# Altium schematic sheet medibox.SchDoc
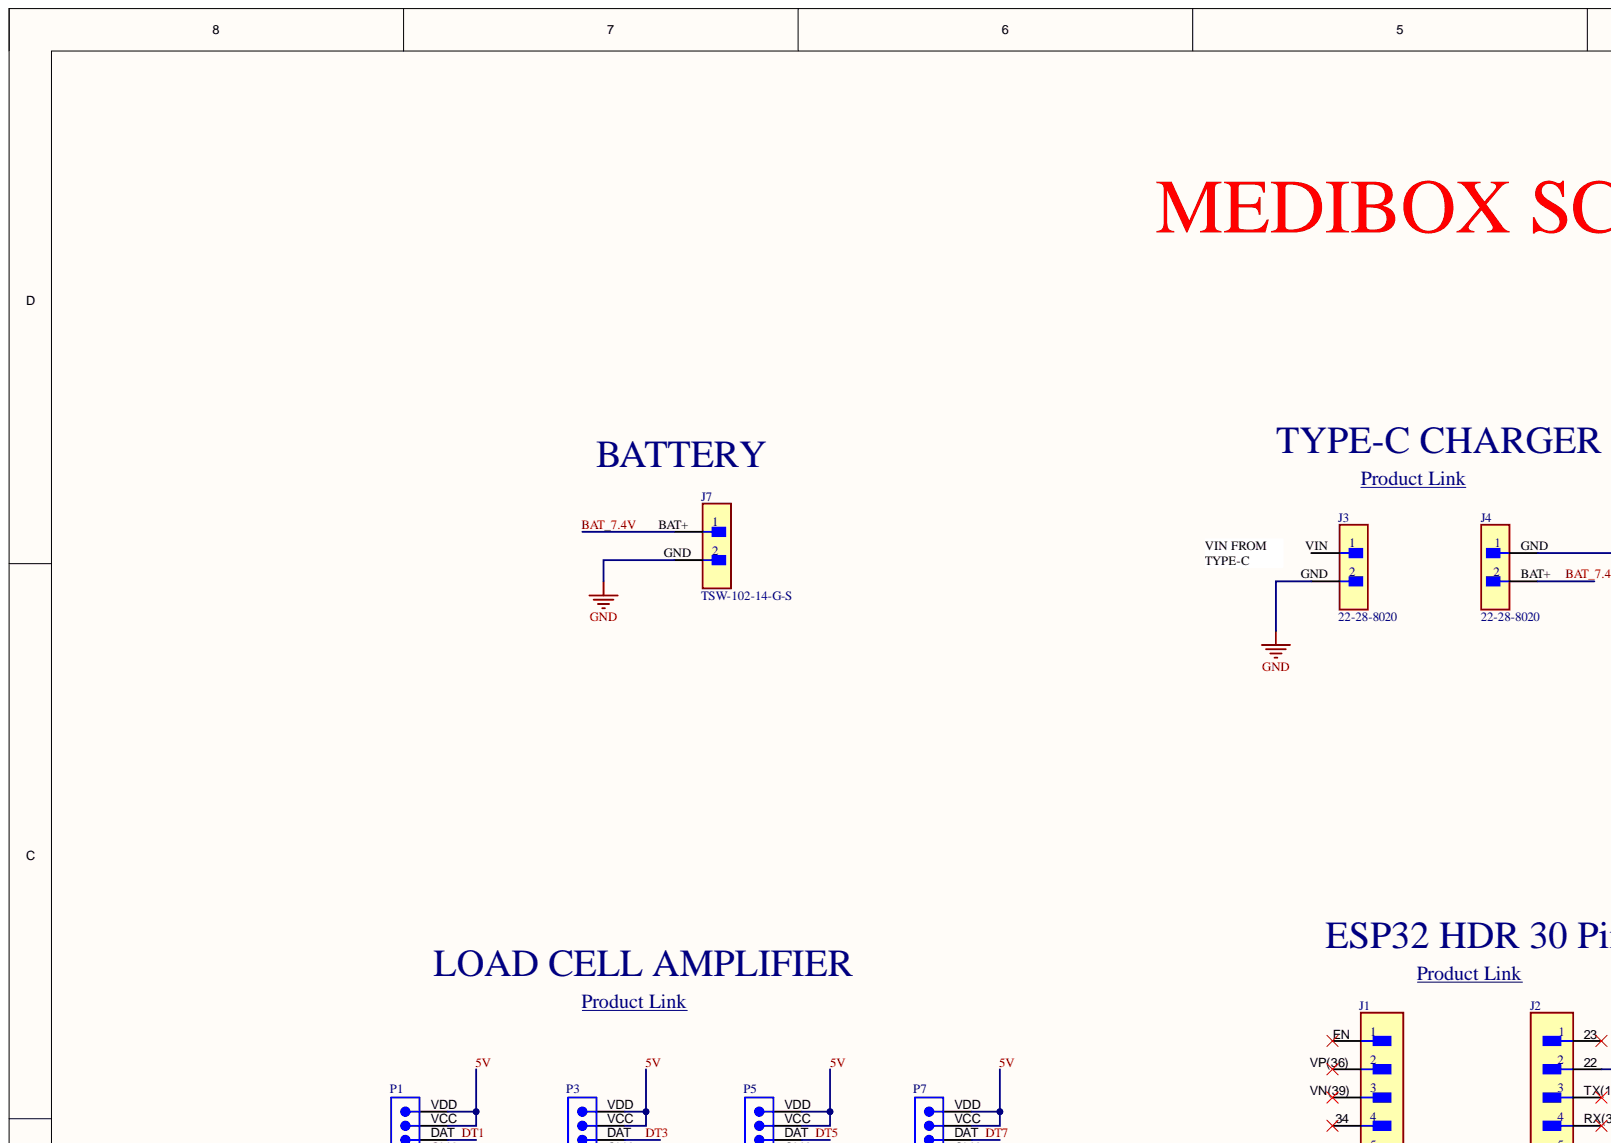
[diagram p0: medibox.SchDoc, top left]
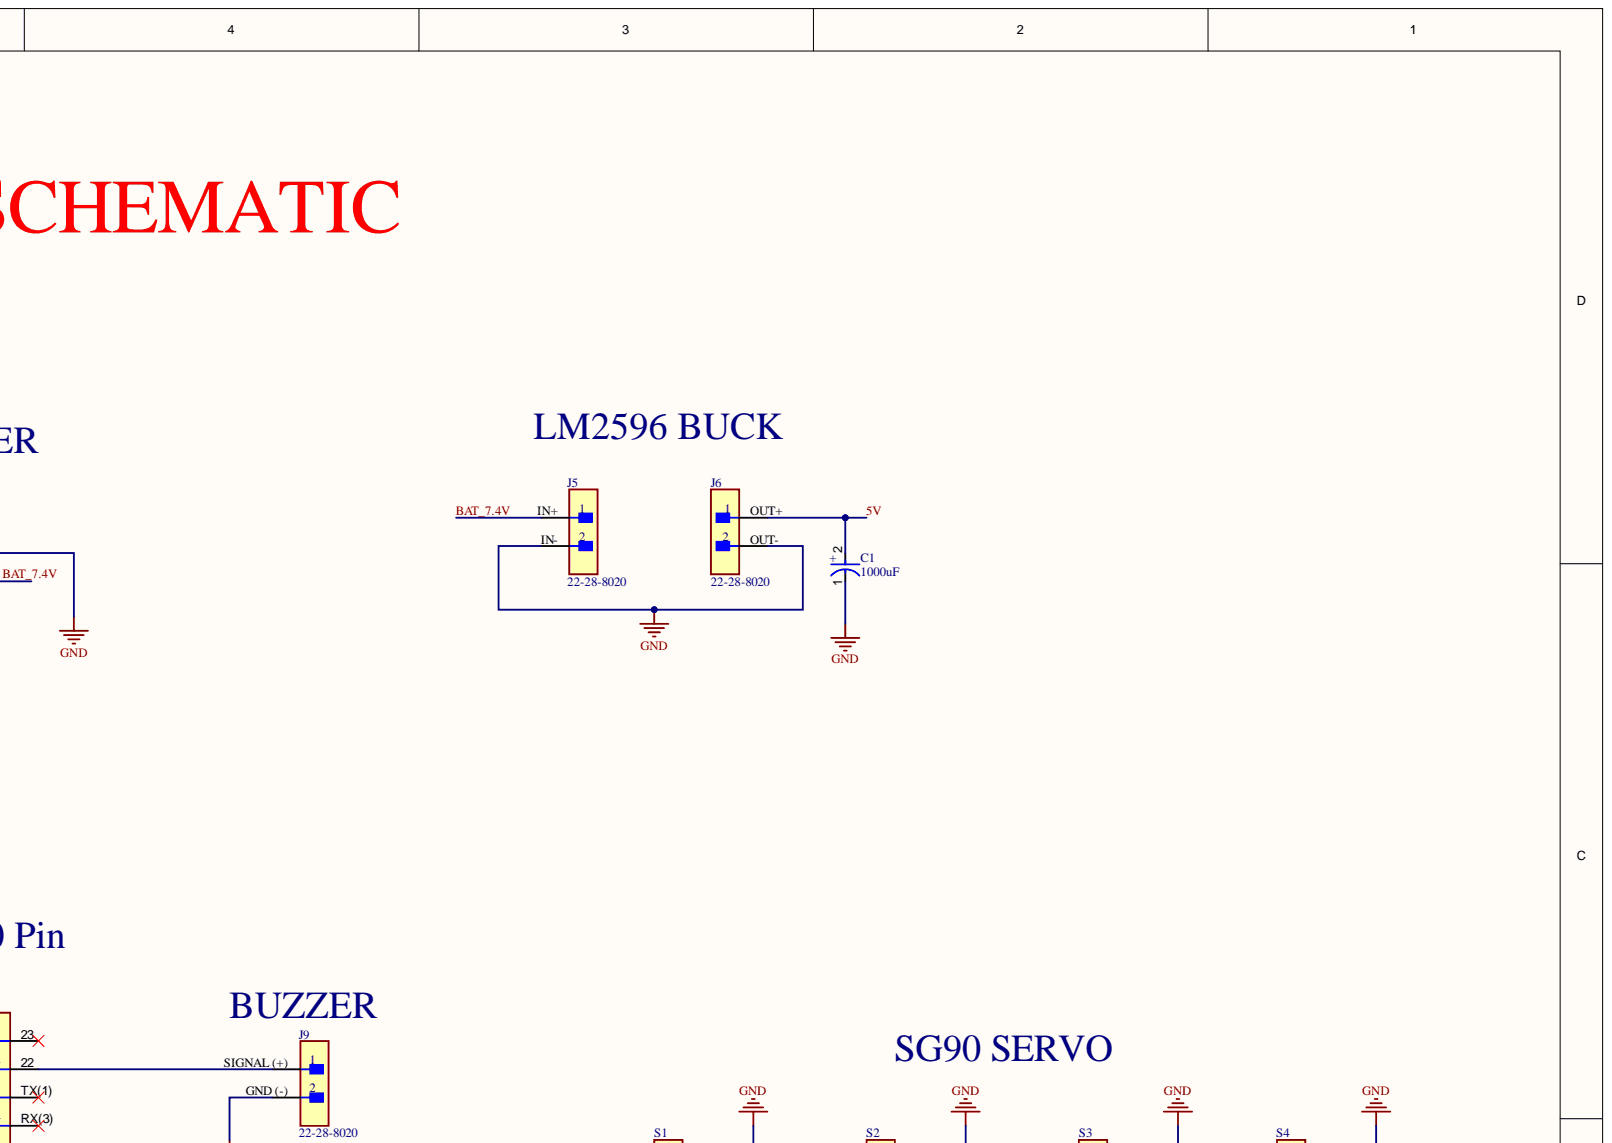
[diagram p1: medibox.SchDoc, top right]
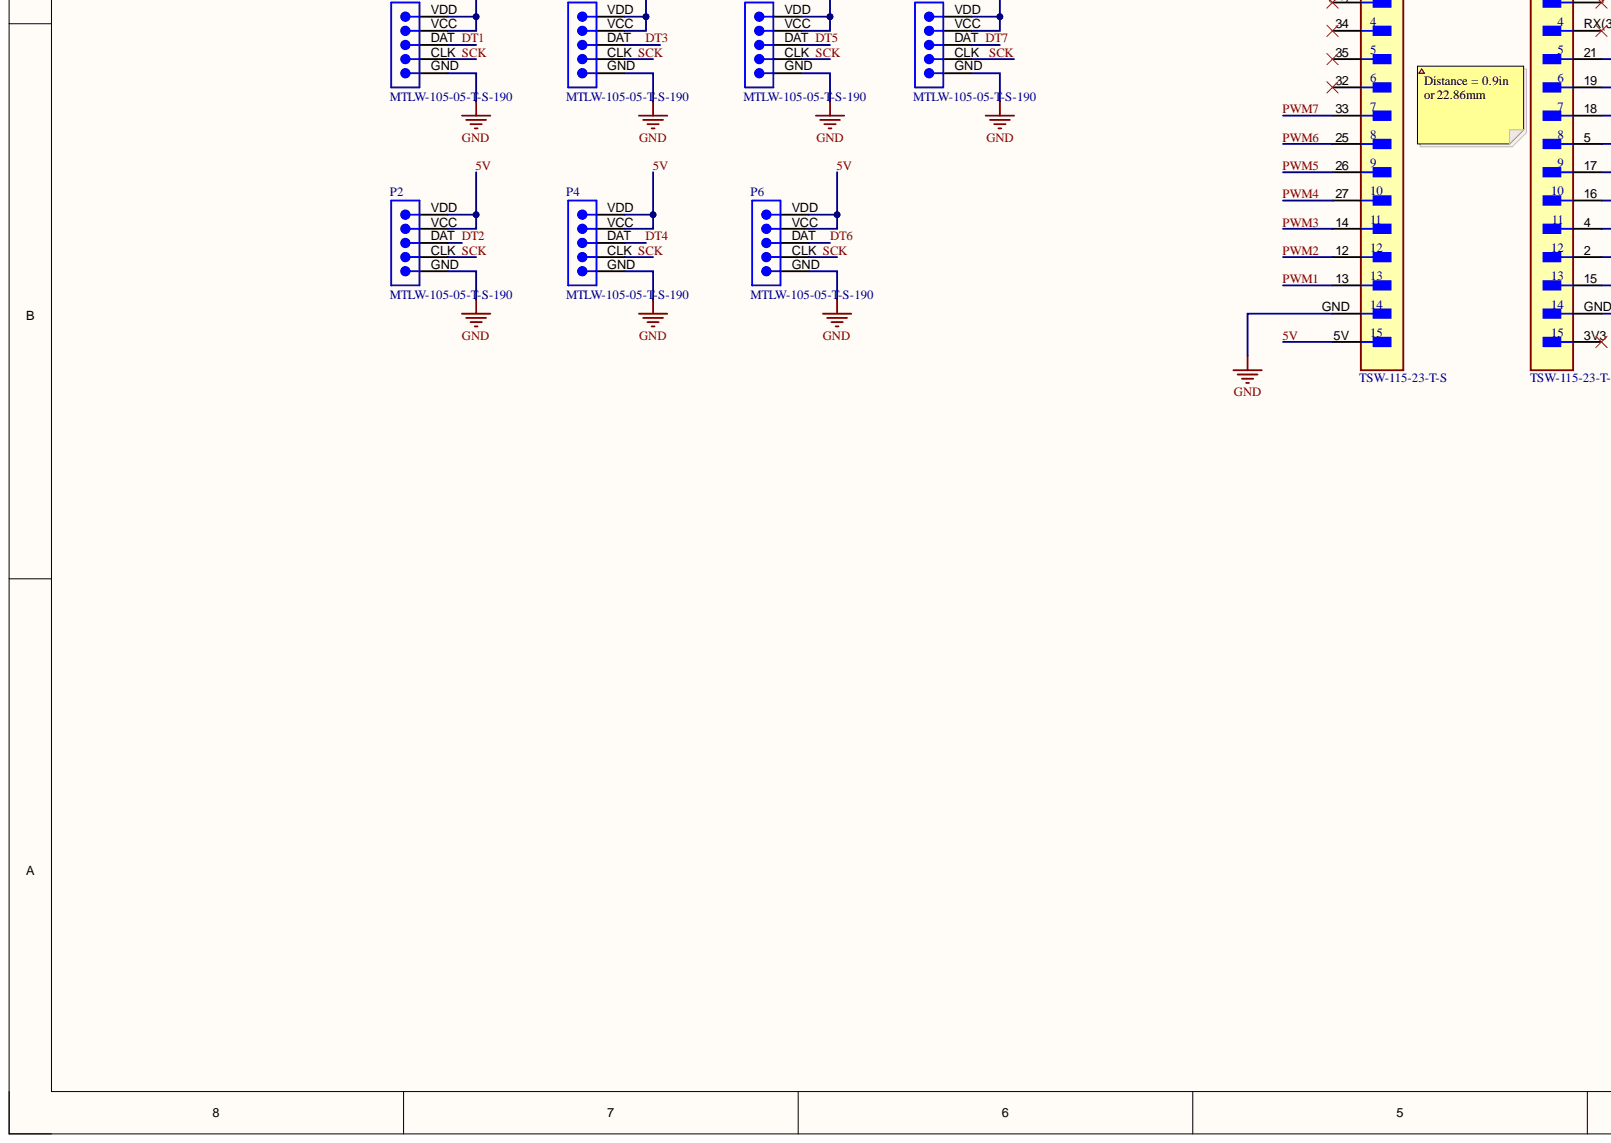
[diagram p2: medibox.SchDoc, bottom left]
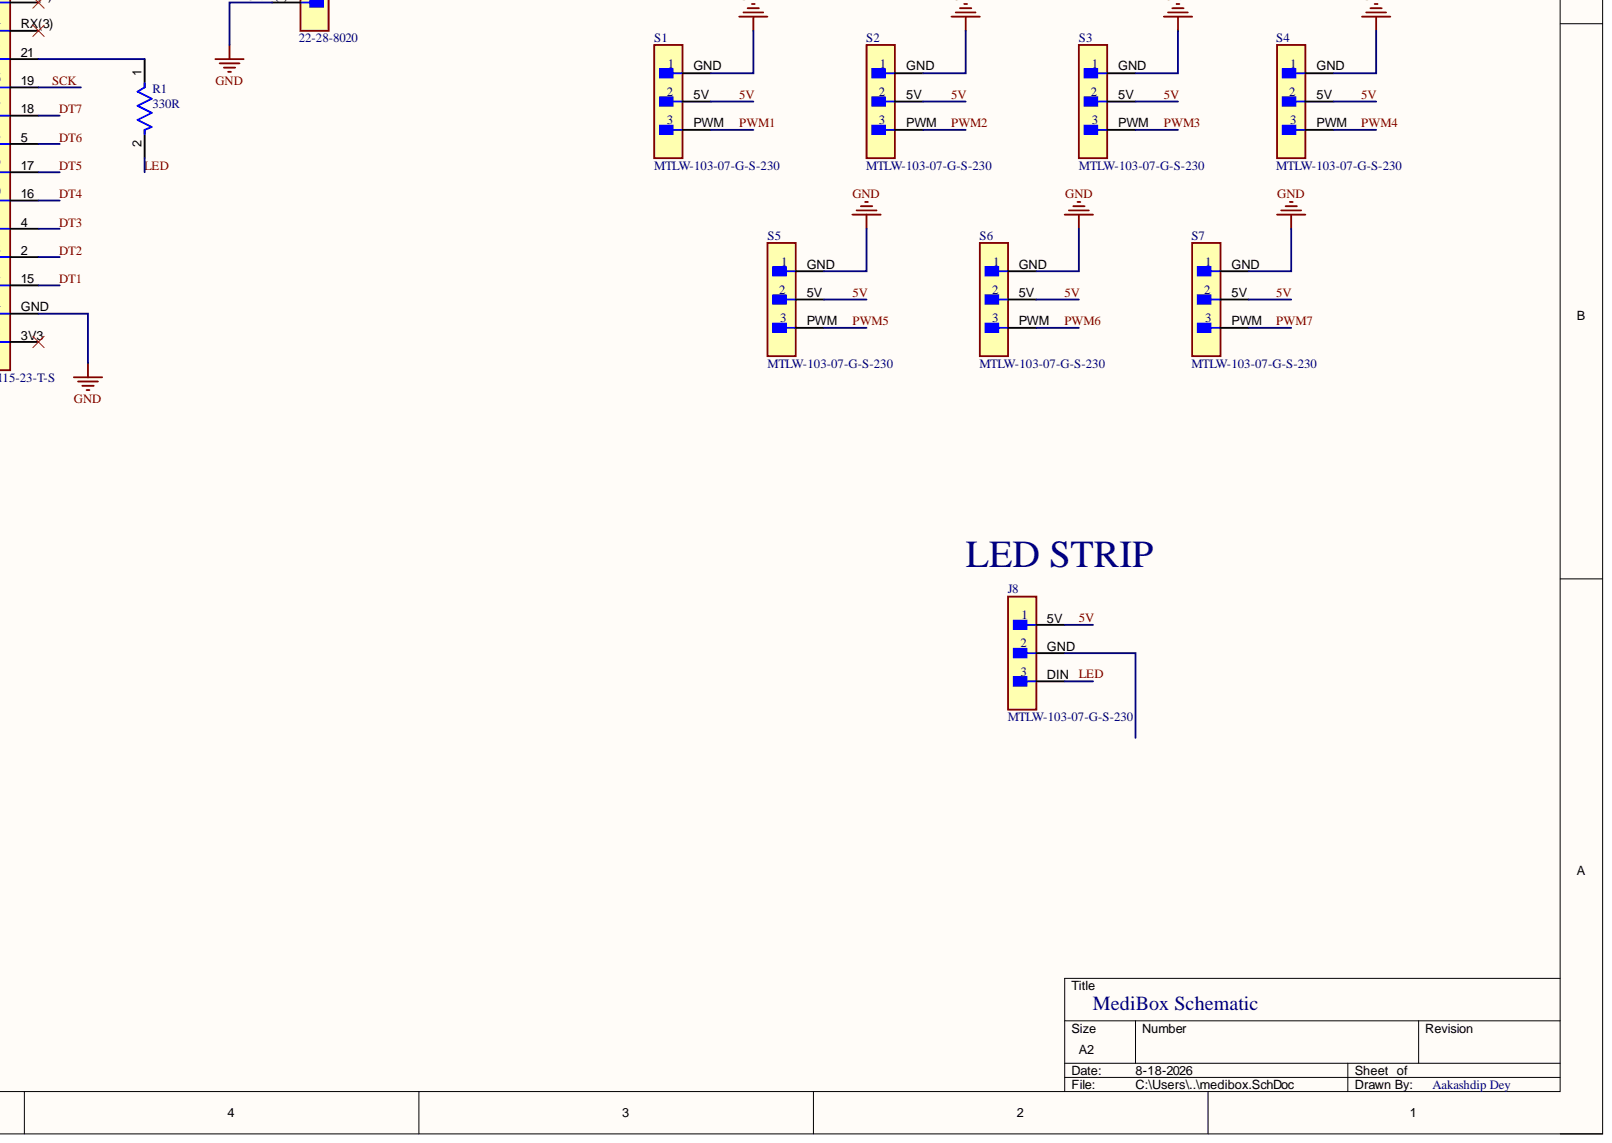
[diagram p3: medibox.SchDoc, bottom right]

## Parts
C1  1000uF  [FP-RAD-TH-D_10_0_5-L_25_2-MFG]
J1  TSW-115-23-T-S  [FP-TSW-115-23-T-S-MFG]
J2  TSW-115-23-T-S  [FP-TSW-115-23-T-S-MFG]
J3  22-28-8020  [FP-22-28-8020-MFG]
J4  22-28-8020  [FP-22-28-8020-MFG]
J5  22-28-8020  [FP-22-28-8020-MFG]
J6  22-28-8020  [FP-22-28-8020-MFG]
J7  TSW-102-14-G-S  [FP-TSW-102-14-G-S-MFG]
J8  MTLW-103-07-G-S-230  [FP-MTLW-103-07-G-S-230-MFG]
J9  22-28-8020  [FP-22-28-8020-MFG]
P1  MTLW-105-05-T-S-190  [SMTC-MTLW-105-05-X-S-190]
P2  MTLW-105-05-T-S-190  [SMTC-MTLW-105-05-X-S-190]
P3  MTLW-105-05-T-S-190  [SMTC-MTLW-105-05-X-S-190]
P4  MTLW-105-05-T-S-190  [SMTC-MTLW-105-05-X-S-190]
P5  MTLW-105-05-T-S-190  [SMTC-MTLW-105-05-X-S-190]
P6  MTLW-105-05-T-S-190  [SMTC-MTLW-105-05-X-S-190]
P7  MTLW-105-05-T-S-190  [SMTC-MTLW-105-05-X-S-190]
R1  330R  [FP-RMCF0805-IPC_C]
S1  MTLW-103-07-G-S-230  [FP-MTLW-103-07-G-S-230-MFG]
S2  MTLW-103-07-G-S-230  [FP-MTLW-103-07-G-S-230-MFG]
S3  MTLW-103-07-G-S-230  [FP-MTLW-103-07-G-S-230-MFG]
S4  MTLW-103-07-G-S-230  [FP-MTLW-103-07-G-S-230-MFG]
S5  MTLW-103-07-G-S-230  [FP-MTLW-103-07-G-S-230-MFG]
S6  MTLW-103-07-G-S-230  [FP-MTLW-103-07-G-S-230-MFG]
S7  MTLW-103-07-G-S-230  [FP-MTLW-103-07-G-S-230-MFG]

## Nets
GND: C1.1 J1.GND J2.GND J3.GND J4.GND J5.IN- J6.OUT- J7.GND J9.GND (-) P1.GND P2.GND P3.GND P4.GND P5.GND P6.GND P7.GND S1.GND S2.GND S3.GND S4.GND S5.GND S6.GND S7.GND
5V: C1.2 J1.5V J6.OUT+ J8.5V P1.VCC P1.VDD P2.VCC P2.VDD P3.VCC P3.VDD P4.VCC P4.VDD P5.VCC P5.VDD P6.VCC P6.VDD P7.VCC P7.VDD S1.5V S2.5V S3.5V S4.5V S5.5V S6.5V S7.5V
BAT_7.4V: J4.BAT+ J5.IN+ J7.BAT+
DT1: J2.15 P1.DAT
DT2: J2.2 P2.DAT
DT3: J2.4 P3.DAT
DT4: J2.16 P4.DAT
DT5: J2.17 P5.DAT
DT6: J2.5 P6.DAT
DT7: J2.18 P7.DAT
LED: J8.DIN R1.2
PWM1: J1.13 S1.PWM
PWM2: J1.12 S2.PWM
PWM3: J1.14 S3.PWM
PWM4: J1.27 S4.PWM
PWM5: J1.26 S5.PWM
PWM6: J1.25 S6.PWM
PWM7: J1.33 S7.PWM
SCK: J2.19 P1.CLK P2.CLK P3.CLK P4.CLK P5.CLK P6.CLK P7.CLK
NetJ2_21: J2.21 R1.1
NetJ2_22: J2.22 J9.SIGNAL (+)

Not connected: J1 32 34 35 EN VN(39) VP(36); J2 3V3 23 RX(3) TX(1); J3 VIN; J8 GND

## Library data of the parts
C1 = CMP-05427-002159-1: EEUFC1C102B Aluminum Electrolytic Capacitors (Radial Lead Type) AEC-Q200 Qualified 1000uF ±20% 16V; Capacitance: 1mF; ESR (Equivalent Series Resistance): 45mR; Lead Diameter: 0.6mm; Life (Hours): 3000Hour; Polarity: Polar; Ripple Current: 1.44A; Case/Package: Radial; Component Kind: Standard; Diameter: 10mm; Height: 25mm; Height - Seated (Max): 1.063inch; Impedance: 45mR; Lead Pitch: 5mm; Length: 25mm; Library Name: Altium Content Vault; Max Operating Temperature: 105°C; Min Operating Temperature: -55°C; Mount: Through Hole; Package Quantity: 500; Packaging: Ammo Pack; Radiation Hardening: No; Ratings: AEC-Q200; RoHS Compliant: Yes; Series: FC; Termination: Radial; Tolerance: 20%; Voltage Rating: 16V; Voltage Rating (DC): 16V
J1 J2 = CMP-02766-001466-1: CONN HEADER VERT 15POS 2.54MM; Connector Type: Male Pin; Gender: Male; Number of Contacts: 15; Pitch: 0.1inch; Color: Black; Component Kind: Standard; Contact Material: Bronze; Contact Mating Length: 0.23inch; Contact Plating: Tin; Contact Type: Male Pin; Height: 2.54mm; Housing Color: Black; Housing Material: Plastic; Lead Free: Lead Free; Length: 38.1mm; Library Name: Altium Content Vault; Mount: Through Hole; Number of Positions: 15; Number of Rows: 1; Packaging: Bulk; Radiation Hardening: No; REACH SVHC: No SVHC; RoHS Compliant: Yes; Series: TSW; Termination: Solder; Width: 2.54mm
J3-J6 J9 = CMP-00257-00131383-2: 22-28-8020 Board Connector, 2 Contact(S), 1 Row(S), Male, Right Angle, 0.1 Inch Pitch, Solder Terminal,; Manufacturer Lifecycle Status: ACTIVE; NexarPartId: 131383; Contact Resistance: 20mΩ; Current Rating: 4A; Glow Wire Compliant: Non-compliant; Max Voltage Rating (DC): 250.25kV; Number of Circuits: 2; Pitch: 2.54mm; Plating: Tin; Voltage Rating: 250V; Color: Black; Component Kind: Standard; Contact Material: Brass; Contact Plating: Tin; Country of Origin: US; Flammability Rating: UL94 V-0; Gender: Male; Height: 2.74mm; Housing Color: Black; Housing Material: Thermoplastic; Lead Free: Lead Free; Lead Pitch: 2.54mm; Library Name: Altium Content Vault; Lifecycle Status: Production; Max Operating Temperature: 75°C; Min Operating Temperature: -40°C; Mount: Through Hole; Number of Contacts: 2; Number of Positions: 2; Number of Rows: 1; Orientation: Right Angle; Packaging: Bulk; Radiation Hardening: No; REACH SVHC: Unknown; RoHS: Compliant; Termination: Through Hole; Voltage Rating (DC): 250V
J7 = CMP-02766-001740-1: CONN HEADER VERT 2POS 2.54MM; Gender: Male; Max Current Rating: 7.6A; Max Voltage Rating (AC): 550V; Number of Contacts: 2; Color: Black; Component Kind: Standard; Connector Type: Header; Contact Finish - Mating: GOLD; Contact Material: Bronze; Contact Mating Length: 0.32inch; Contact Plating: Gold; Contact Shape: Square; Contact Type: Male Pin; Depth: 2.54mm; Fastening Type: Pull; Flammability Rating: UL94 V-0; Housing Color: Black; Housing Material: Polyester; Insulation Color: Black; Insulation Material: PBT; Lead Free: Lead Free; Length: 5.08mm; Library Name: Altium Content Vault; Max Operating Temperature: 125°C; Min Operating Temperature: -55°C; Mount: Through Hole; Number of Positions: 2; Number of Rows: 1; Orientation: Straight; Package Quantity: 1; Packaging: Bulk; Pitch: 2.54mm; Radiation Hardening: No; REACH SVHC: No SVHC; RoHS Compliant: Yes; Series: TSW; Termination: Solder; Width: 2.54mm
J8 S1-S7 = CMP-02766-001679-1: CONN HEADER VERT 3POS 2.54MM; Gender: Male; Max Current Rating: 3A; Number of Contacts: 3; Component Kind: Standard; Contact Material: Bronze; Contact Plating: Gold; Depth: 2.48mm; Housing Color: Black; Housing Material: Polymer; Length: 7.62mm; Library Name: Altium Content Vault; Max Operating Temperature: 125°C; Min Operating Temperature: -55°C; Number of Rows: 1; Orientation: Straight; Packaging: Bulk; Pitch: 2.54mm; Radiation Hardening: No; RoHS Compliant: Yes; Termination: Solder
P1-P7 = CMP-1024-00077-1: Low Profile .025" SQ Post Header, Through-hole, Vertical, -55 to 105 degC, 2.54 mm Pitch, 5-Pin, Male, RoHS; Manufacturer: Samtec; Pitch: 2.54 mm; Component Kind: Standard; ComponentLink1Description: Manufacturer URL; ComponentLink1URL: http://www.samtec.com; ComponentLink2Description: Datasheet; ComponentLink2URL: http://www.samtec.com/documents/webfiles/pdf/MTLW_TH.PDF; ComponentLink3Description: Package Specification; ComponentLink3URL: http://www.samtec.com/documents/webfiles/cpdf/MTLW-1XX-XX-XX-X-XXX-XX-XX-MKT1.pdf; DatasheetVersion: F-214; Library Name: Altium Content Vault; Mounting Technology: Through-hole; Orientation: Vertical; PackageDescription: Low Profile Terminal Assembly; PackageReference: MTLW-105-05-X-S-190; PackageVersion: T, 6/1991; PartNumber: MTLW-105-05-T-S-190; RoHS: True; Temperature Range: -55 to 105 degC
R1 = CMP-26527-000132-1: RMCF0805FT330R 330Ω ±1% 0.125W 0805 Thick Film Chip Resistor AEC-Q200 compliant; Max Power Dissipation: 125mW; Temperature Coefficient: 100ppm/°C; Case Code (Imperial): 0805; Case Code (Metric): 2012; Case/Package: 0805; Component Kind: Standard; Construction: Rectangular; Contact Plating: Tin; Depth: 1.25mm; Features: Automotive AEC-Q200; Height: 0.026inch; Lead Free: Lead Free; Length: 0.079inch; Library Name: Altium Content Vault; Max Operating Temperature: 155°C; Military Standard: Not; Min Operating Temperature: -55°C; Mount: Surface Mount; Number of Terminations: 2; Packaging: Tape and Reel; Radiation Hardening: No; Resistance: 330R; Resistor Type: Thick Film; RoHS Compliant: Yes; Series: RMCF; Tolerance: 1%; Width: 0.049inch
